# Altium schematic sheet MCU_IOs.SchDoc
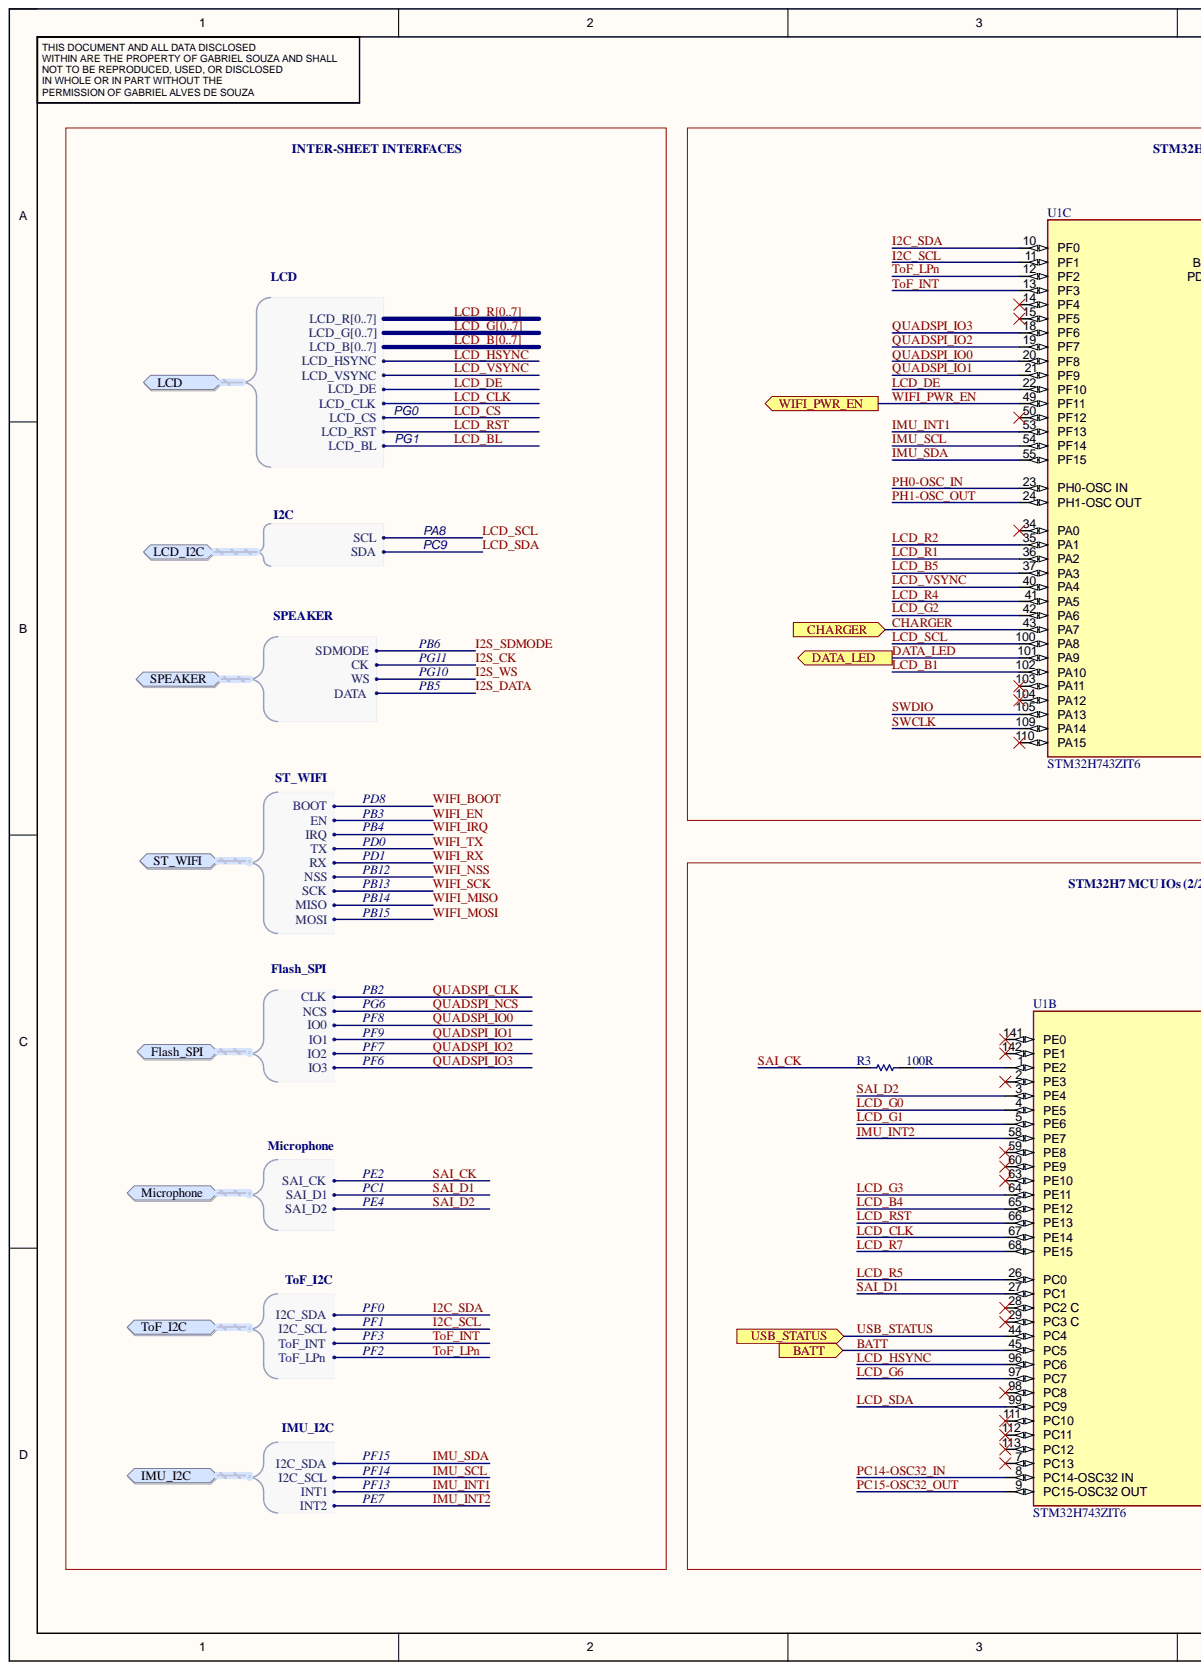
[diagram p0: MCU_IOs.SchDoc, left]
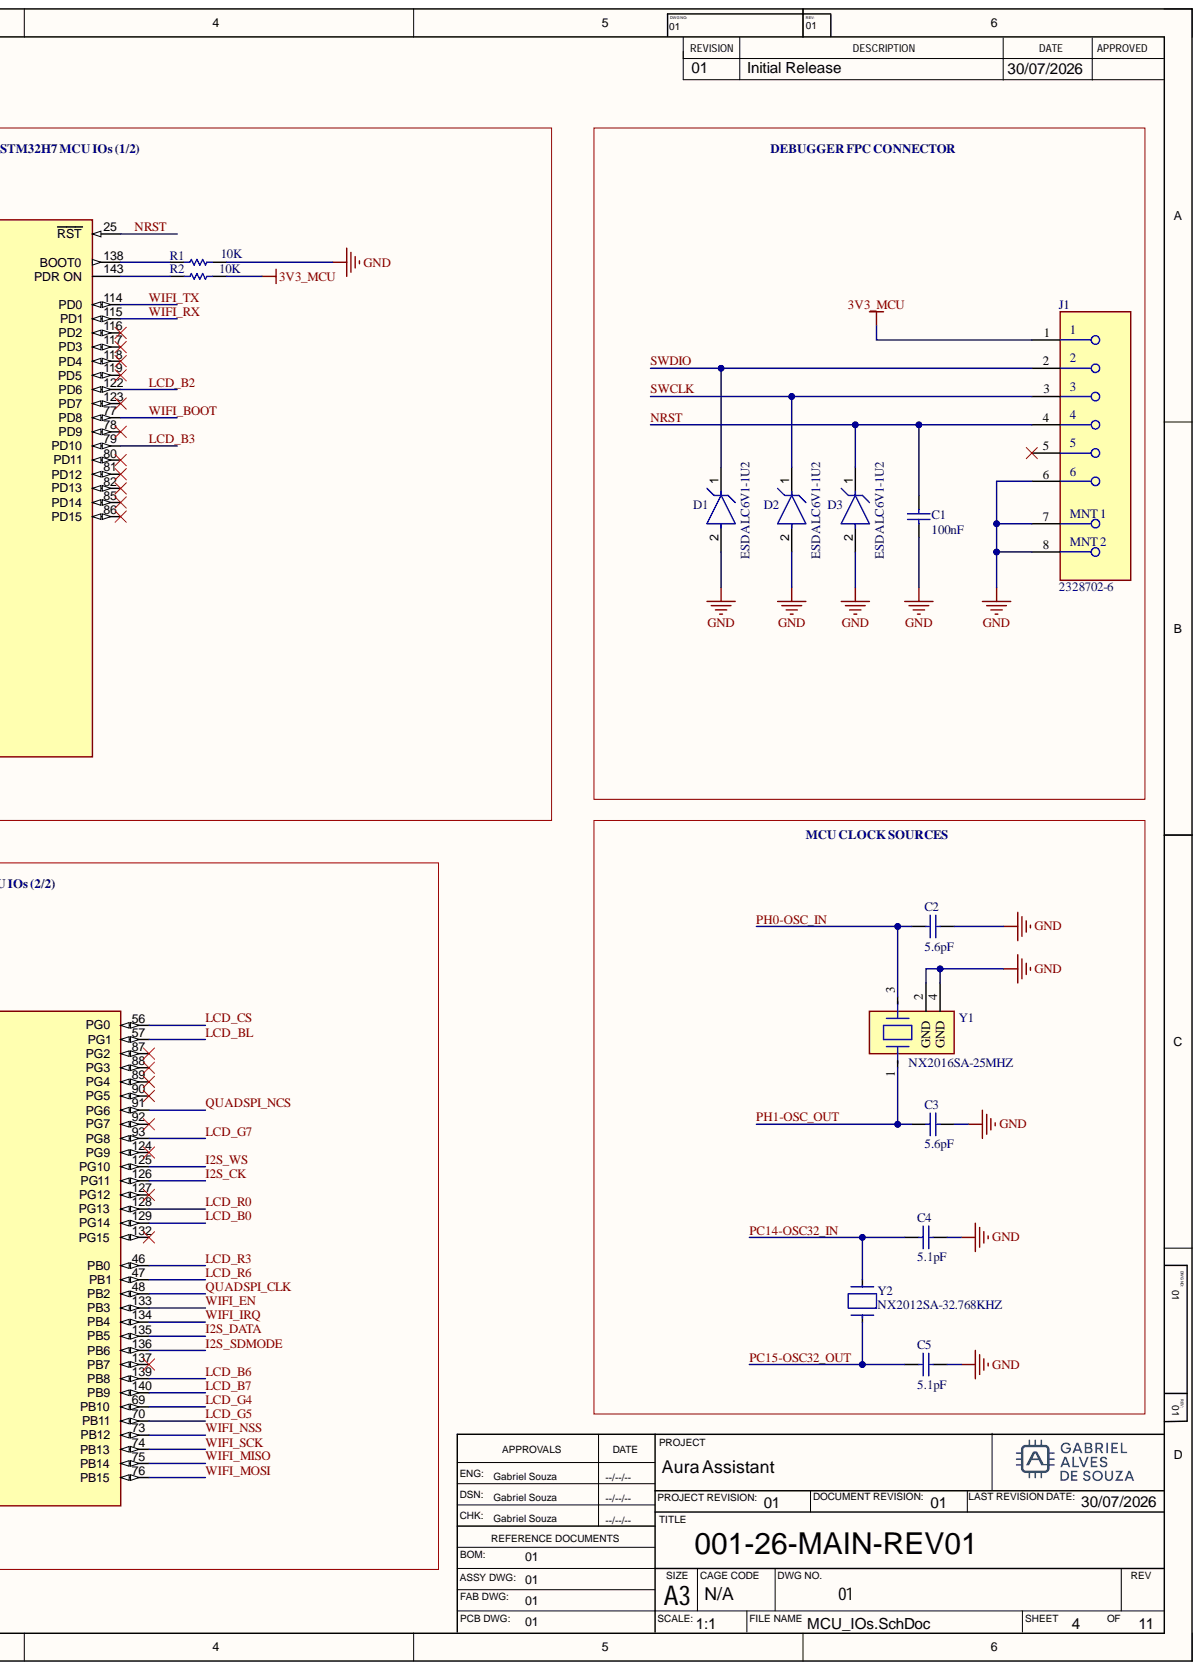
[diagram p1: MCU_IOs.SchDoc, right]

## Parts
C1  value 100nF  [C_0402_IPC_N]  (hidden comment: Capacitor)
C2  value 5.6pF  [C_0402_IPC_N]  (hidden comment: Capacitor)
C3  value 5.6pF  [C_0402_IPC_N]  (hidden comment: Capacitor)
C4  value 5.1pF  [C_0402_IPC_N]  (hidden comment: Capacitor)
C5  value 5.1pF  [C_0402_IPC_N]  (hidden comment: Capacitor)
D1  ESDALC6V1-1U2  [FP-ST0201-MFG]
D2  ESDALC6V1-1U2  [FP-ST0201-MFG]
D3  ESDALC6V1-1U2  [FP-ST0201-MFG]
J1  2328702-6  [FP-2328702-6-MFG]
R1  value 10K  [R_0603_IPC_N]  (hidden comment: Resistor)
R2  value 10K  [R_0603_IPC_N]  (hidden comment: Resistor)
R3  value 100R  [R_0603_IPC_N]  (hidden comment: Resistor)
U1  STM32H743ZIT6  [FP-LQFP144-1A-MFG]  (parts B,C)
Y1  NX2016SA-25MHZ  [FP-NX2016SA-MFG]
Y2  NX2012SA-32.768KHZ  [FP-NX2012SA-MFG]

## Ports
BATT, CHARGER, DATA_LED, Flash_SPI, Flash_SPI.CLK, Flash_SPI.IO0, Flash_SPI.IO1, Flash_SPI.IO2, Flash_SPI.IO3, Flash_SPI.NCS, IMU_I2C, IMU_I2C.I2C_SCL, IMU_I2C.I2C_SDA, IMU_I2C.INT1, IMU_I2C.INT2, LCD, LCD.LCD_B0, LCD.LCD_B1, LCD.LCD_B2, LCD.LCD_B3, LCD.LCD_B4, LCD.LCD_B5, LCD.LCD_B6, LCD.LCD_B7, LCD.LCD_B[0..7], LCD.LCD_BL, LCD.LCD_CLK, LCD.LCD_CS, LCD.LCD_DE, LCD.LCD_G0, LCD.LCD_G1, LCD.LCD_G2, LCD.LCD_G3, LCD.LCD_G4, LCD.LCD_G5, LCD.LCD_G6, LCD.LCD_G7, LCD.LCD_G[0..7], LCD.LCD_HSYNC, LCD.LCD_R0, LCD.LCD_R1, LCD.LCD_R2, LCD.LCD_R3, LCD.LCD_R4, LCD.LCD_R5, LCD.LCD_R6, LCD.LCD_R7, LCD.LCD_R[0..7], LCD.LCD_RST, LCD.LCD_VSYNC, LCD_I2C, LCD_I2C.SCL, LCD_I2C.SDA, Microphone, Microphone.SAI_CK, Microphone.SAI_D1, Microphone.SAI_D2, SPEAKER, SPEAKER.CK, SPEAKER.DATA, SPEAKER.SDMODE, SPEAKER.WS, ST_WIFI, ST_WIFI.BOOT, ST_WIFI.EN, ST_WIFI.IRQ, ST_WIFI.MISO, ST_WIFI.MOSI, ST_WIFI.NSS, ST_WIFI.RX, ST_WIFI.SCK, ST_WIFI.TX, ToF_I2C, ToF_I2C.I2C_SCL, ToF_I2C.I2C_SDA, ToF_I2C.ToF_INT, ToF_I2C.ToF_LPn, USB_STATUS, WIFI_PWR_EN

## Nets
3V3_MCU: J1.1 R2.1
GND: C1.1 C2.1 C3.1 C4.1 C5.1 D1.2 D2.2 D3.2 J1.6 J1.7 J1.8 R1.1 Y1.2(GND) Y1.4(GND)
BATT: U1.45(PC5) ; port BATT
CHARGER: U1.43(PA7) ; port CHARGER
DATA_LED: U1.101(PA9) ; port DATA_LED
I2C_SCL: U1.11(PF1) ; port ToF_I2C
I2C_SDA: U1.10(PF0) ; port ToF_I2C
I2S_CK: U1.126(PG11) ; port SPEAKER
I2S_DATA: U1.135(PB5) ; port SPEAKER
I2S_SDMODE: U1.136(PB6) ; port SPEAKER
I2S_WS: U1.125(PG10) ; port SPEAKER
IMU_INT1: U1.53(PF13) ; port IMU_I2C
IMU_INT2: U1.58(PE7) ; port IMU_I2C
IMU_SCL: U1.54(PF14) ; port IMU_I2C
IMU_SDA: U1.55(PF15) ; port IMU_I2C
LCD_B0: U1.129(PG14) ; port LCD  [also labelled LCD_B]
LCD_B1: U1.102(PA10) ; port LCD  [also labelled LCD_B]
LCD_B2: U1.122(PD6) ; port LCD  [also labelled LCD_B]
LCD_B3: U1.79(PD10) ; port LCD  [also labelled LCD_B]
LCD_B4: U1.65(PE12) ; port LCD  [also labelled LCD_B]
LCD_B5: U1.37(PA3) ; port LCD  [also labelled LCD_B]
LCD_B6: U1.139(PB8) ; port LCD  [also labelled LCD_B]
LCD_B7: U1.140(PB9) ; port LCD  [also labelled LCD_B]
LCD_BL: U1.57(PG1) ; port LCD
LCD_CLK: U1.67(PE14) ; port LCD
LCD_CS: U1.56(PG0) ; port LCD
LCD_DE: U1.22(PF10) ; port LCD
LCD_G0: U1.4(PE5) ; port LCD  [also labelled LCD_G]
LCD_G1: U1.5(PE6) ; port LCD  [also labelled LCD_G]
LCD_G2: U1.42(PA6) ; port LCD  [also labelled LCD_G]
LCD_G3: U1.64(PE11) ; port LCD  [also labelled LCD_G]
LCD_G4: U1.69(PB10) ; port LCD  [also labelled LCD_G]
LCD_G5: U1.70(PB11) ; port LCD  [also labelled LCD_G]
LCD_G6: U1.97(PC7) ; port LCD  [also labelled LCD_G]
LCD_G7: U1.93(PG8) ; port LCD  [also labelled LCD_G]
LCD_HSYNC: U1.96(PC6) ; port LCD
LCD_R0: U1.128(PG13) ; port LCD  [also labelled LCD_R]
LCD_R1: U1.36(PA2) ; port LCD  [also labelled LCD_R]
LCD_R2: U1.35(PA1) ; port LCD  [also labelled LCD_R]
LCD_R3: U1.46(PB0) ; port LCD  [also labelled LCD_R]
LCD_R4: U1.41(PA5) ; port LCD  [also labelled LCD_R]
LCD_R5: U1.26(PC0) ; port LCD  [also labelled LCD_R]
LCD_R6: U1.47(PB1) ; port LCD  [also labelled LCD_R]
LCD_R7: U1.68(PE15) ; port LCD  [also labelled LCD_R]
LCD_RST: U1.66(PE13) ; port LCD
LCD_SCL: U1.100(PA8) ; port LCD_I2C
LCD_SDA: U1.99(PC9) ; port LCD_I2C
LCD_VSYNC: U1.40(PA4) ; port LCD
NRST: C1.2 D3.1 J1.4 U1.25(RST)
PC14-OSC32_IN: C4.2 U1.8(PC14-OSC32_IN) Y2.2
PC15-OSC32_OUT: C5.2 U1.9(PC15-OSC32_OUT) Y2.1
PH0-OSC_IN: C2.2 U1.23(PH0-OSC_IN) Y1.3
PH1-OSC_OUT: C3.2 U1.24(PH1-OSC_OUT) Y1.1
QUADSPI_CLK: U1.48(PB2) ; port Flash_SPI
QUADSPI_IO0: U1.20(PF8) ; port Flash_SPI
QUADSPI_IO1: U1.21(PF9) ; port Flash_SPI
QUADSPI_IO2: U1.19(PF7) ; port Flash_SPI
QUADSPI_IO3: U1.18(PF6) ; port Flash_SPI
QUADSPI_NCS: U1.91(PG6) ; port Flash_SPI
SAI_CK: R3.2 ; port Microphone
SAI_D1: U1.27(PC1) ; port Microphone
SAI_D2: U1.3(PE4) ; port Microphone
SWCLK: D2.1 J1.3 U1.109(PA14)
SWDIO: D1.1 J1.2 U1.105(PA13)
ToF_INT: U1.13(PF3) ; port ToF_I2C
ToF_LPn: U1.12(PF2) ; port ToF_I2C
USB_STATUS: U1.44(PC4) ; port USB_STATUS
WIFI_BOOT: U1.77(PD8) ; port ST_WIFI
WIFI_EN: U1.133(PB3) ; port ST_WIFI
WIFI_IRQ: U1.134(PB4) ; port ST_WIFI
WIFI_MISO: U1.75(PB14) ; port ST_WIFI
WIFI_MOSI: U1.76(PB15) ; port ST_WIFI
WIFI_NSS: U1.73(PB12) ; port ST_WIFI
WIFI_PWR_EN: U1.49(PF11) ; port WIFI_PWR_EN
WIFI_RX: U1.115(PD1) ; port ST_WIFI
WIFI_SCK: U1.74(PB13) ; port ST_WIFI
WIFI_TX: U1.114(PD0) ; port ST_WIFI
NetR1_2: R1.2 U1.138(BOOT0)
NetR2_2: R2.2 U1.143(PDR_ON)
NetR3_1: R3.1 U1.1(PE2)

Not connected: J1 5; U1 2(PE3) 7(PC13) 14(PF4) 15(PF5) 28(PC2_C) 29(PC3_C) 34(PA0) 50(PF12) 59(PE8) 60(PE9) 63(PE10) 78(PD9) 80(PD11) 81(PD12) 82(PD13) 85(PD14) 86(PD15) 87(PG2) 88(PG3) 89(PG4) 90(PG5) 92(PG7) 98(PC8) 103(PA11) 104(PA12) 110(PA15) 111(PC10) 112(PC11) 113(PC12) 116(PD2) 117(PD3) 118(PD4) 119(PD5) 123(PD7) 124(PG9) 127(PG12) 132(PG15) 137(PB7) 141(PE0) 142(PE1)

## Library data of the parts
C1 = CAP: Capacitor, MLCC, 100nF, 16V, X7R, +/-10%, 0402, T=0,5mm; Manufacturer: TDK; Manufacturer_Part_Number: C1005X7R1C104KT000F; Component Kind: Standard; ComponentLink1Description: Datasheet; ComponentLink1URL: https://www.lcsc.com/datasheet/C338032.pdf?spm=wm.sxq.inf.ggs___wm.fly.bg.6.xh&lcsc_vid=TlIKVlcFRVJWVl1WQVFfBlQDRFgPAVVQRFhaBQIFFgQxVlNeRVRbU1RQQ1dWUTtW; Order_Code_LCSC: C338032; Package: 0402; Library Name: Gabriel_Lib.IntLib
C2 C3 = CAP: Capacitor, MLCC, 5.6pF, 50V, C0G, +/-10%, 0402, T=0,5mm; Manufacturer: muRata; Manufacturer_Part_Number: GRM1555C1H5R6CA01J; Component Kind: Standard; ComponentLink1Description: Datasheet; ComponentLink1URL: https://www.lcsc.com/datasheet/C338032.pdf?spm=wm.sxq.inf.ggs___wm.fly.bg.6.xh&lcsc_vid=TlIKVlcFRVJWVl1WQVFfBlQDRFgPAVVQRFhaBQIFFgQxVlNeRVRbU1RQQ1dWUTtW; Order_Code_LCSC: C3861666; Package: 0402; Library Name: Gabriel_Lib.IntLib
C4 C5 = CAP: Capacitor, MLCC, 5.1pF, 50V, C0G, +/-10%, 0402, T=0,5mm; Manufacturer: muRata; Manufacturer_Part_Number: GJM1555C1H5R1WB01D; Component Kind: Standard; ComponentLink1Description: Datasheet; ComponentLink1URL: https://www.lcsc.com/datasheet/C338032.pdf?spm=wm.sxq.inf.ggs___wm.fly.bg.6.xh&lcsc_vid=TlIKVlcFRVJWVl1WQVFfBlQDRFgPAVVQRFhaBQIFFgQxVlNeRVRbU1RQQ1dWUTtW; Order_Code_LCSC: C437506; Package: 0402; Library Name: Gabriel_Lib.IntLib
D1-D3 = CMP-12225-000007-1: TVS DIODE 3V ST0201; Manufacturer: STMicroelectronics; Breakdown Voltage: 6.1V; Capacitance: 12pF; Direction: Unidirectional; Max Junction Temp: +125°C; Number Of Channels: 1; Peak Pulse Current: 2A; Peak Pulse Power: 20W; Value: 12pF; Automotive: No; Category: Diode; Component Kind: Standard; ComponentLink2Description: Datasheet; ComponentLink2URL: https://www.st.com/content/ccc/resource/technical/document/datasheet/90/b1/aa/a3/9f/73/4d/1e/CD00212838.pdf/files/CD00212838.pdf/jcr:content/translations/en.CD00212838.pdf; Device Class L1: Circuit Protection; Device Class L2: TVS Diodes; Device Class L3: unset; Digikey Description: TVS DIODE 3V ST0201; Height: 0.33mm; Lead Free: Yes; Library Name: Altium Content Vault; Manufacturer Part Number: ESDALC6V1-1U2; Mouser Description: TVS Diodes - Transient Voltage Suppressors Single-Line Low Cap ESD TVS 0201; Order_Code_LCSC: C1974498; Package: ST0201; RoHS: TRUE; Temperature: -40°C to +125°C; Temperature Range High: +125°C; Temperature Range Low: -40°C
J1 = CMP-03368-000003-1: 0.5MM PITCH DUAL CONTACT BACK FL; Actuator Material: Thermoplastic; Capacitance: 7.6pF; Current Rating: 500mA; Number of Positions: 6; Voltage Rating: 50V; Case/Package: 0402; Component Kind: Standard; Contact Material: Copper Alloy; Contact Plating: Gold; Flammability Rating: UL94 V-0; Housing Color: Natural; Housing Material: Thermoplastic; Library Name: Altium Content Vault; Max Operating Temperature: 85°C; Min Operating Temperature: -40°C; Mount: Surface Mount; Order_Code_LCSC: C3151647; Packaging: Tape and Reel; Ratings: AEC-Q200; Termination: Solder
R1 R2 = RES: Resistor, 10K, 0603, 0.1%, 0.1W; Manufacturer: UNI-ROYAL; Manufacturer_Part_Number: TC0350B1002T5F; Component Kind: Standard; ComponentLink1Description: Datasheet; Order_Code_LCSC: C2989264; Package: 0603; Part_Type: Resistor; Library Name: Gabriel_Lib.IntLib
R3 = RES: Resistor, 100R, 0603, 0.1%, 0.1W; Manufacturer: YAGEO; Manufacturer_Part_Number: RT0603BRD07100RL; Component Kind: Standard; ComponentLink1Description: Datasheet; Order_Code_LCSC: C122970; Package: 0603; Part_Type: Resistor; Library Name: Gabriel_Lib.IntLib
U1 = CMP-12105-000003-1: IC MCU 32BIT 2MB FLASH 144LQFP; Manufacturer: STMicroelectronics; Core Architecture: ARM Cortex-M7; Data Bus Width: 32bits; Frequency: 400MHz; Interface: I2C,SPI,UART,USART,EBI/EMI,CAN,USB,LIN,IrDA,Other; Max Junction Temp: +125°C; Max Supply Voltage: 3.6V; Memory Size: 2MBytes; Min Supply Voltage: 1.62V; Number Of A/D Converters: 2; Number Of Adc Channels: 36; Number Of Cores: 1; Number Of I2C Channels: 4; Number Of I/Os: 114; Number Of Spi Channels: 6; Number Of Timers/Counters: 22; Ram Size: 1MBytes; Standoff Height: 0.05mm; Ambient Temperature Range High: +85°C; Ambient Temperature Range Low: -40°C; Automotive: No; Category: IC; Component Kind: Standard; ComponentLink2Description: Datasheet; ComponentLink2URL: https://www.st.com/resource/en/datasheet/stm32h743zi.pdf; Device Class L1: Integrated Circuits (ICs); Device Class L2: Embedded Processors and Controllers; Device Class L3: Microcontrollers; Digikey Description: IC MCU 32BIT 2MB FLASH 144LQFP; Height: 1.6mm; Ipc Land Pattern Name: QFP50P2200X2200X160-144; Lead Free: Yes; Library Name: Altium Content Vault; Manufacturer Part Number: STM32H743ZIT6; Memory Type: FLASH; Mouser Description: MCU 32-Bit STM32 ARM Cortex M7 RISC 2MB Flash 1.8V/2.5V/3.3V 144-Pin LQFP Tray; Number Of Pwm Channels: 2; Number Of Uart Channels: 4; Number Of Usb Channels: 2; Octopart: https://octopart.com/stm32h743zit6-stmicroelectronics-76372529; Package: LQFP144-1A; RoHS: TRUE; Temperature: -40°C to +85°C; Temperature Range High: +85°C; Temperature Range Low: -40°C
Y1 = CMP-200220-000017-1: CRYSTAL 32.0000MHZ 8PF SMD; ESR (Equivalent Series Resistance): 60R; Frequency: 32MHz; Case/Package: SMD/SMT; Component Kind: Standard; Library Name: Altium Content Vault; Load Capacitance: 8pF; Max Operating Temperature: 125°C; Min Operating Temperature: -40°C; Mount: Surface Mount; Order_Code_LCSC: C843258; Packaging: Tape and Reel; Ratings: AEC-Q200
Y2 = CMP-200220-000005-1: CRYSTAL 32.768KHZ 7PF SMD; Manufacturer: NDK America; Manufacturer Part Number: NX2012SA-32.768KHZ-EXS00A-MU00389; Esr: 80kΩ; Load Capacitance: 12.5pF; Tolerance: 20ppm; Automotive: No; Category: Crys; Component Kind: Standard; ComponentLink2Description: Datasheet; ComponentLink2URL: https://www.ndk.com/images/products/catalog/c_NX2012SA-STD-MUB-1_e.pdf; Device Class L1: Passive Components; Device Class L2: Crystals / Oscillators; Device Class L3: Crystals; Digikey Description: CRYSTAL 32.768KHZ 7PF SMD; Frequency: 32.768kHz; Height: 0.6mm; Lead Free: Yes; Library Name: Altium Content Vault; Octopart: https://octopart.com/nx2012sa-32.768khz-exs00a-mu00389-ndk-92010294; Order_Code_LCSC: C889705; Package: NX2012SA; RoHS: TRUE; Series: NX2012SA; Temperature: -40°C to +85°C; Temperature Range High: +85°C; Temperature Range Low: -40°C
